# Altium schematic sheet TopLevel.SchDoc(TopLevel)
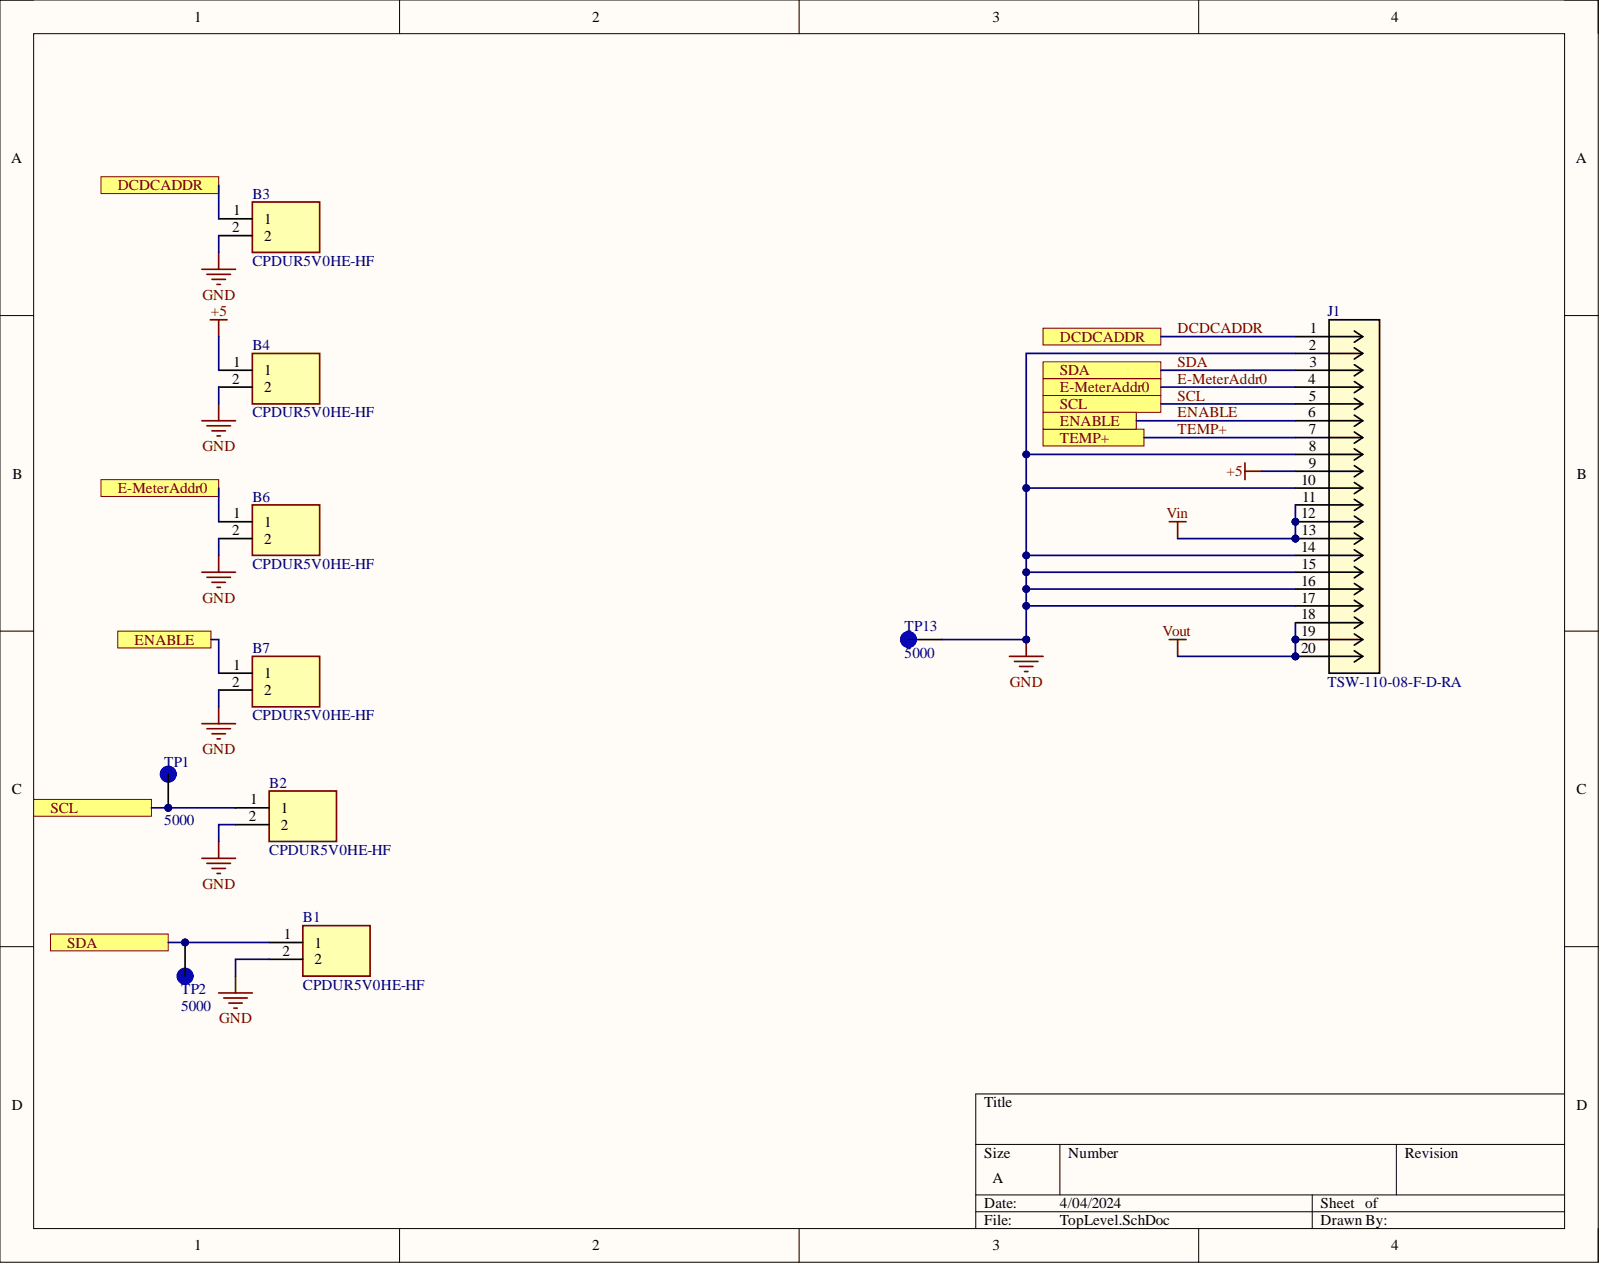
[diagram p0: TopLevel.SchDoc]

## Parts
B1  CPDUR5V0HE-HF
B2  CPDUR5V0HE-HF
B3  CPDUR5V0HE-HF
B4  CPDUR5V0HE-HF
B6  CPDUR5V0HE-HF
B7  CPDUR5V0HE-HF
J1  TSW-110-08-F-D-RA
TP1  5000
TP2  5000
TP13  5000

## Ports
DCDCADDR, E-MeterAddr0, ENABLE, SCL, SDA, TEMP+

## Nets
+5: B4.1 J1.9
GND: B1.2 B2.2 B3.2 B4.2 B6.2 B7.2 J1.2 J1.8 J1.10 J1.14 J1.15 J1.16 J1.17 TP13.TP
Vin: J1.11 J1.12 J1.13
Vout: J1.18 J1.19 J1.20
DCDCADDR: B3.1 J1.1 ; port DCDCADDR
E-MeterAddr0: B6.1 J1.4 ; port E-MeterAddr0
ENABLE: B7.1 J1.6 ; port ENABLE
SCL: B2.1 J1.5 TP1.TP ; port SCL
SDA: B1.1 J1.3 TP2.TP ; port SDA
TEMP+: J1.7 ; port TEMP+

## Library data of the parts
B1-B4 B6 B7 = CMP-051-000235-1: ESD Suppressors / TVS Diodes Bidir,12V; Manufacturer_Name: Comchip Technology; Manufacturer_Part_Number: CPDUR12V-HF; Geometry.Height: 0.85mm; Arrow Part Number: CPDUR12V-HF; Arrow Price/Stock: https://www.arrow.com/en/products/cpdur12v-hf/comchip-technology?region=nac; Component Kind: Standard; Datasheet Link: https://www.arrow.com/en/products/cpdur12v-hf/comchip-technology?region=nac; Design Item ID: CPDUR12V-HF; Source: CPDUR12V-HF.SchLib; Library Name: Illini Formula Electric
J1 = CMP-108-000000-1: No Description Available; Mfr_Name: Samtec Inc; Component Kind: Standard; Component Type: Standard; Design Item ID: TSW-110-08-F-D-RA; Manufacturer_Part_Number: TSW-110-08-F-D-RA; Pin Count: 20; RefDes: RefDes; Source: TSW-110-08-F-D-RA.SchLib; Type: DEV; Copyright: Copyright (C) 2024 Ultra Librarian. All rights reserved.; Library Name: Illini Formula Electric
TP1 TP2 TP13 = CMP-00067-1: Test Point Miniature THM H .300 Nylon 46 Insulated Red PhosBronze/Silver; MF: Keystone Electronics; Check_prices: https://www.snapeda.com/parts/5000/Keystone+Electronics/view-part/?ref=eda; Component Kind: Standard; Component Type: Standard; Design Item ID: 5000; MP: 5000; Package: Test Point Keystone Electronics; Pin Count: 1; SnapEDA_Link: https://www.snapeda.com/parts/5000/Keystone+Electronics/view-part/?ref=snap; Source: 5000.SchLib; Library Name: Illini Formula Electric
